# Altium schematic sheet Input.SchDoc
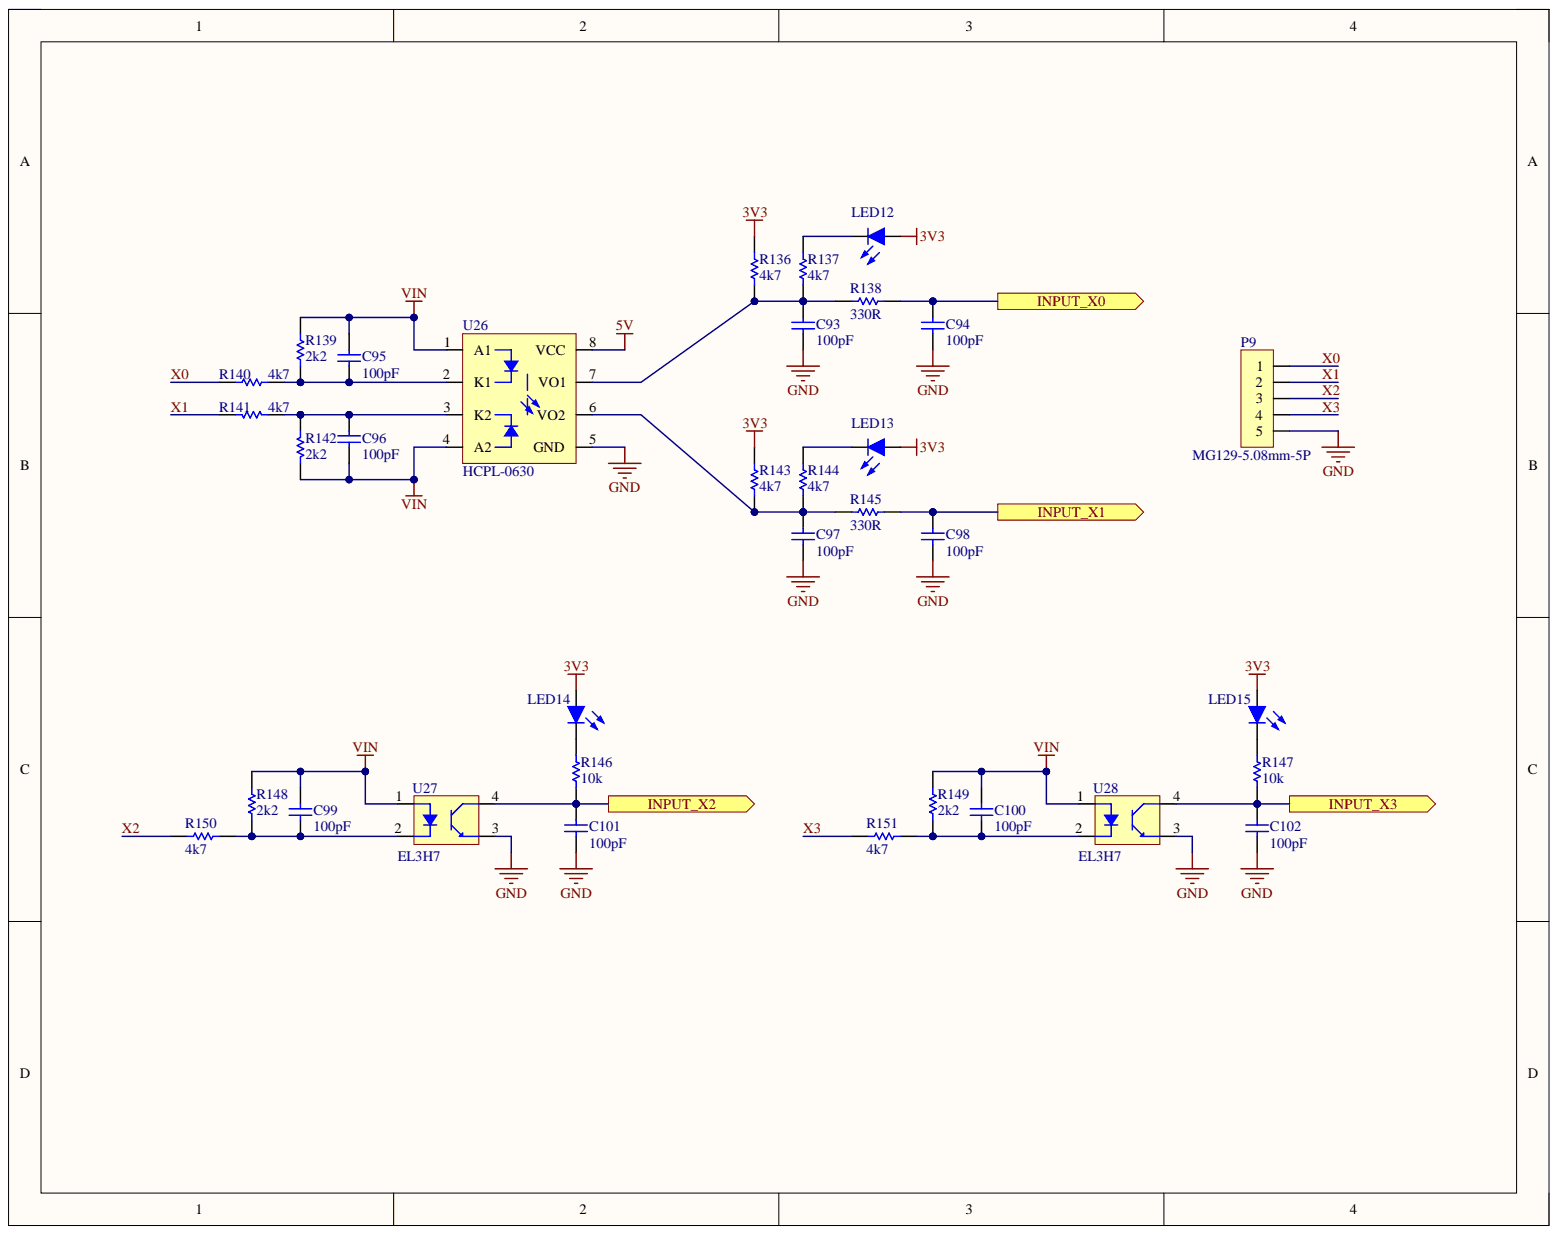
[diagram p0: Input.SchDoc]

## Parts
C93  100pF  [C 0603 (1608)]
C94  100pF  [C 0603 (1608)]
C95  100pF  [C 0603 (1608)]
C96  100pF  [C 0603 (1608)]
C97  100pF  [C 0603 (1608)]
C98  100pF  [C 0603 (1608)]
C99  100pF  [C 0603 (1608)]
C100  100pF  [C 0603 (1608)]
C101  100pF  [C 0603 (1608)]
C102  100pF  [C 0603 (1608)]
LED12  Led-Yellow  [Led SMD 0603 YELLOW]  (value not drawn)
LED13  Led-Yellow  [Led SMD 0603 YELLOW]  (value not drawn)
LED14  Led-Yellow  [Led SMD 0603 YELLOW]  (value not drawn)
LED15  Led-Yellow  [Led SMD 0603 YELLOW]  (value not drawn)
P9  MG129-5.08mm-5P  [HDR Domino 5 - 5MM]
R136  4k7  [R 0603 (1608)]
R137  4k7  [R 0603 (1608)]
R138  330R  [R 0603 (1608)]
R139  2k2  [R 0603 (1608)]
R140  4k7  [R 0603 (1608)]
R141  4k7  [R 0603 (1608)]
R142  2k2  [R 0603 (1608)]
R143  4k7  [R 0603 (1608)]
R144  4k7  [R 0603 (1608)]
R145  330R  [R 0603 (1608)]
R146  10k  [R 0603 (1608)]
R147  10k  [R 0603 (1608)]
R148  2k2  [R 0603 (1608)]
R149  2k2  [R 0603 (1608)]
R150  4k7  [R 0603 (1608)]
R151  4k7  [R 0603 (1608)]
U26  HCPL-0630  [SOIC-8]
U27  EL3H7  [SOIC-4]
U28  EL3H7  [SOIC-4]

## Ports
INPUT_X0, INPUT_X1, INPUT_X2, INPUT_X3

## Nets
3V3: LED12.1 LED13.1 LED14.1 LED15.1 R136.2 R143.2
5V: U26.8(VCC)
GND: C93.2 C94.2 C97.2 C98.2 C101.2 C102.2 P9.5 U26.5(GND) U27.3 U28.3
VIN: C95.1 C96.1 C99.1 C100.1 R139.2 R142.2 R148.2 R149.2 U26.1(A1) U26.4(A2) U27.1 U28.1
INPUT_X0: C94.1 R138.1 ; port INPUT_X0
INPUT_X1: C98.1 R145.1 ; port INPUT_X1
INPUT_X2: C101.1 R146.1 U27.4 ; port INPUT_X2
INPUT_X3: C102.1 R147.1 U28.4 ; port INPUT_X3
X0: P9.1 R140.2
X1: P9.2 R141.2
X2: P9.3 R150.2
X3: P9.4 R151.2
NetC93_1: C93.1 R136.1 R137.1 R138.2 U26.7(VO1)
NetC95_2: C95.2 R139.1 R140.1 U26.2(K1)
NetC96_2: C96.2 R141.1 R142.1 U26.3(K2)
NetC97_1: C97.1 R143.1 R144.1 R145.2 U26.6(VO2)
NetC99_2: C99.2 R148.1 R150.1 U27.2
NetC100_2: C100.2 R149.1 R151.1 U28.2
NetLED12_2: LED12.2 R137.2
NetLED13_2: LED13.2 R144.2
NetLED14_2: LED14.2 R146.2
NetLED15_2: LED15.2 R147.2

## Library data of the parts
C93-C102 = Capacitor NP: Capacitor non-pol; Component Kind: Standard; Library Name: BKIT4U_LIB.SchLib
LED12-LED15 = Led: Typical LED; Component Kind: Standard; Library Name: BKIT4U_LIB.SchLib
P9 = Header 5: Header, 5-Pin; Component Kind: Standard; LatestRevisionDate: 17-Jul-2002; LatestRevisionNote: Re-released for DXP Platform.; Library Name: BKIT4U_LIB.SchLib; Publisher: Altium Limited
R136-R151 = Resistor: Component Kind: Standard; Description: Resistor; LatestRevisionDate: 17-Jul-2002; LatestRevisionNote: Re-released for DXP Platform.; Library Name: BKIT4U_LIB.SchLib; PackageReference: AXIAL-0.3; Published: 8-Jun-2000; Publisher: Altium Limited
U27 U28 = Opto PC817B: Component Kind: Standard; Library Name: BKIT4U_LIB.SchLib
U26 = HCPL-0630: Component Kind: Standard; Library Name: BKIT4U_LIB.SchLib
